# Altium schematic sheet Debug.SchDoc
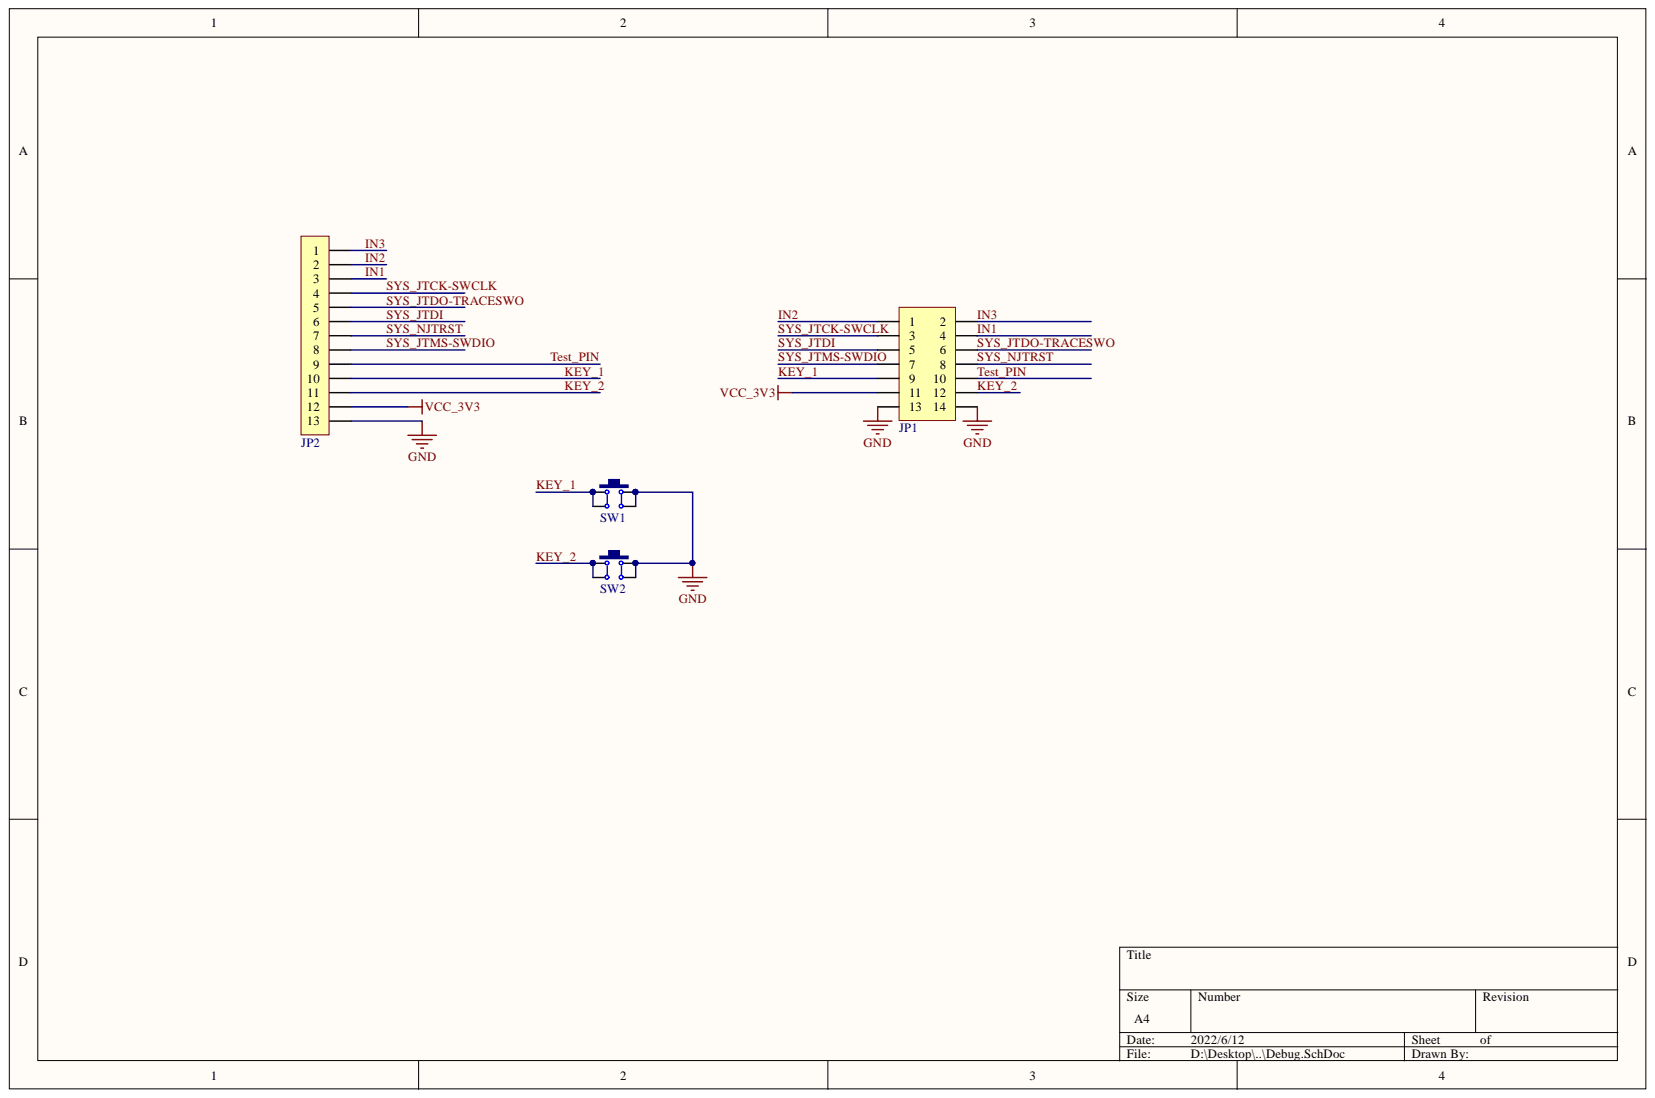
[diagram p0: Debug.SchDoc]

## Parts
JP1  Header 2X7  [HDR2.54-LI-2x7P]  (value not drawn)
JP2  HDR-1X13  [FPC0.5-WT-13P]  (value not drawn)
SW1  6x6x5  [TSW SMD-3*6*4.3]  (value not drawn)
SW2  6x6x5  [TSW SMD-3*6*4.3]  (value not drawn)

## Nets
GND: JP1.13 JP1.14 JP2.13 SW1.2 SW2.2
VCC_3V3: JP1.11 JP2.12
IN1: JP1.4 JP2.3
IN2: JP1.1 JP2.2
IN3: JP1.2 JP2.1
KEY_1: JP1.9 JP2.10 SW1.1
KEY_2: JP1.12 JP2.11 SW2.1
SYS_JTCK-SWCLK: JP1.3 JP2.4
SYS_JTDI: JP1.5 JP2.6
SYS_JTDO-TRACESWO: JP1.6 JP2.5
SYS_JTMS-SWDIO: JP1.7 JP2.8
SYS_NJTRST: JP1.8 JP2.7
Test_PIN: JP1.10 JP2.9

## Library data of the parts
JP1 = Header 7X2: 7*2P接插件; BOM名称: 7*2P接插件; Component Kind: Standard; Library Name: 5.3 - 接插件-脚距2.54 (100mil) .SchLib
JP2 = Header 13: 13P连接器; 联系卖家 免费技术支持: 封装库来自淘宝卖家ID：黄晶宝石; BOM名称: 13P连接器; Component Kind: Standard; Library Name: 5.1 - 1.25mm连接器(FPC1.25_GH1.25_MX1.25).SchLib
SW1 SW2 = TSW SMD-6x6: 贴片6x6轻触开关; BOM名称: 贴片6x6轻触开关; Component Kind: Standard; Library Name: 0.7 - 常用按键-开关.SchLib
